# Altium schematic sheet SolderIronOut.SchDoc
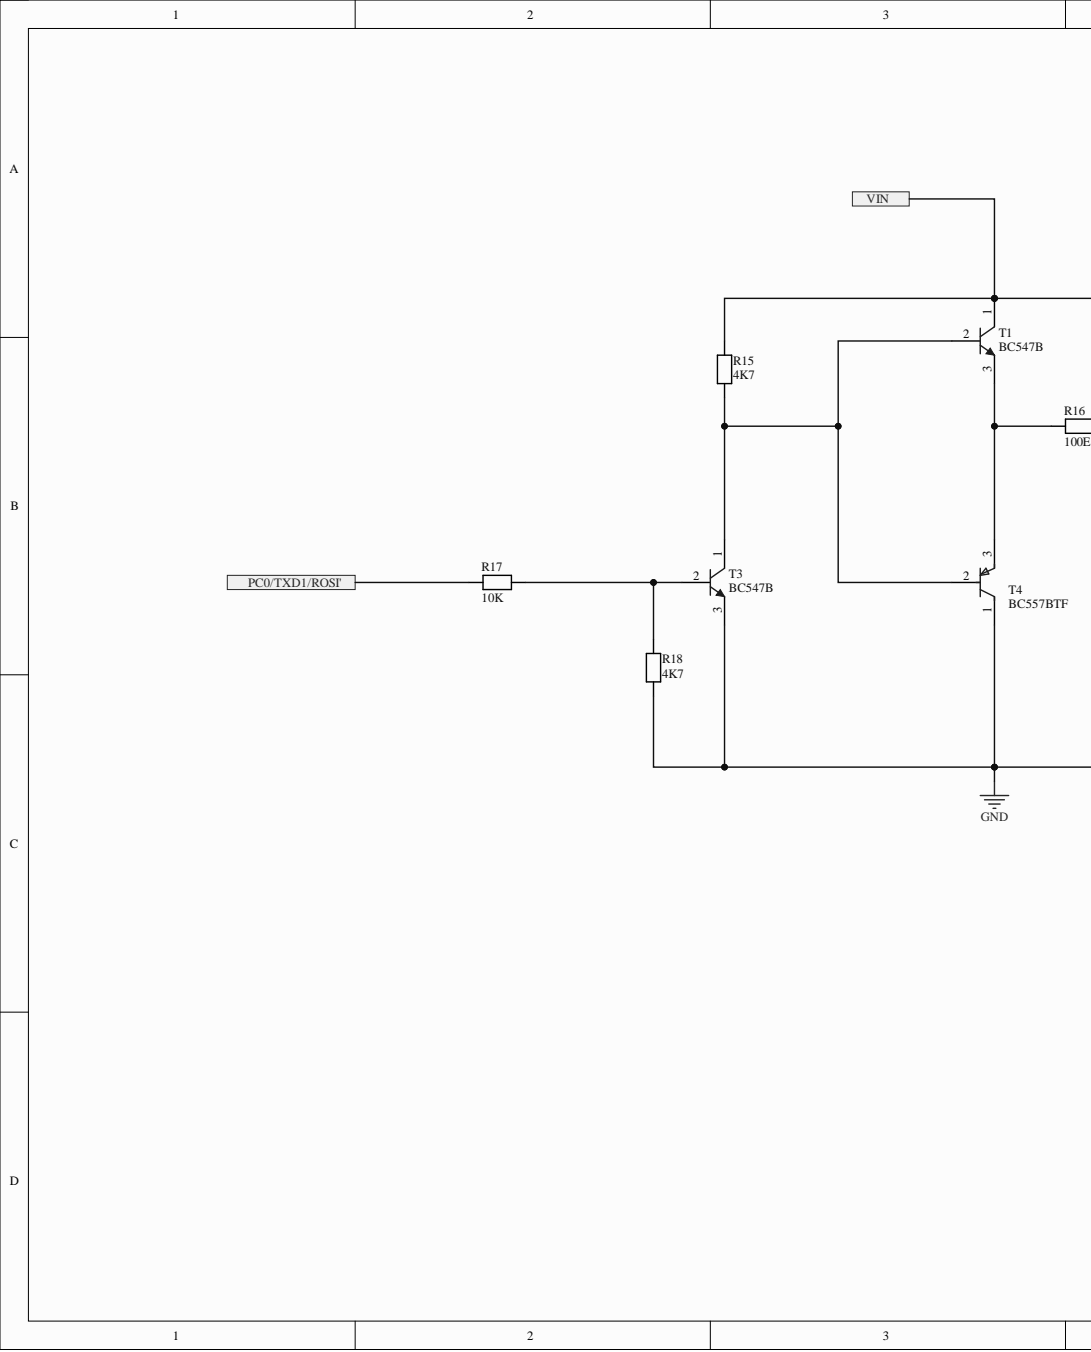
[diagram p0: SolderIronOut.SchDoc, left]
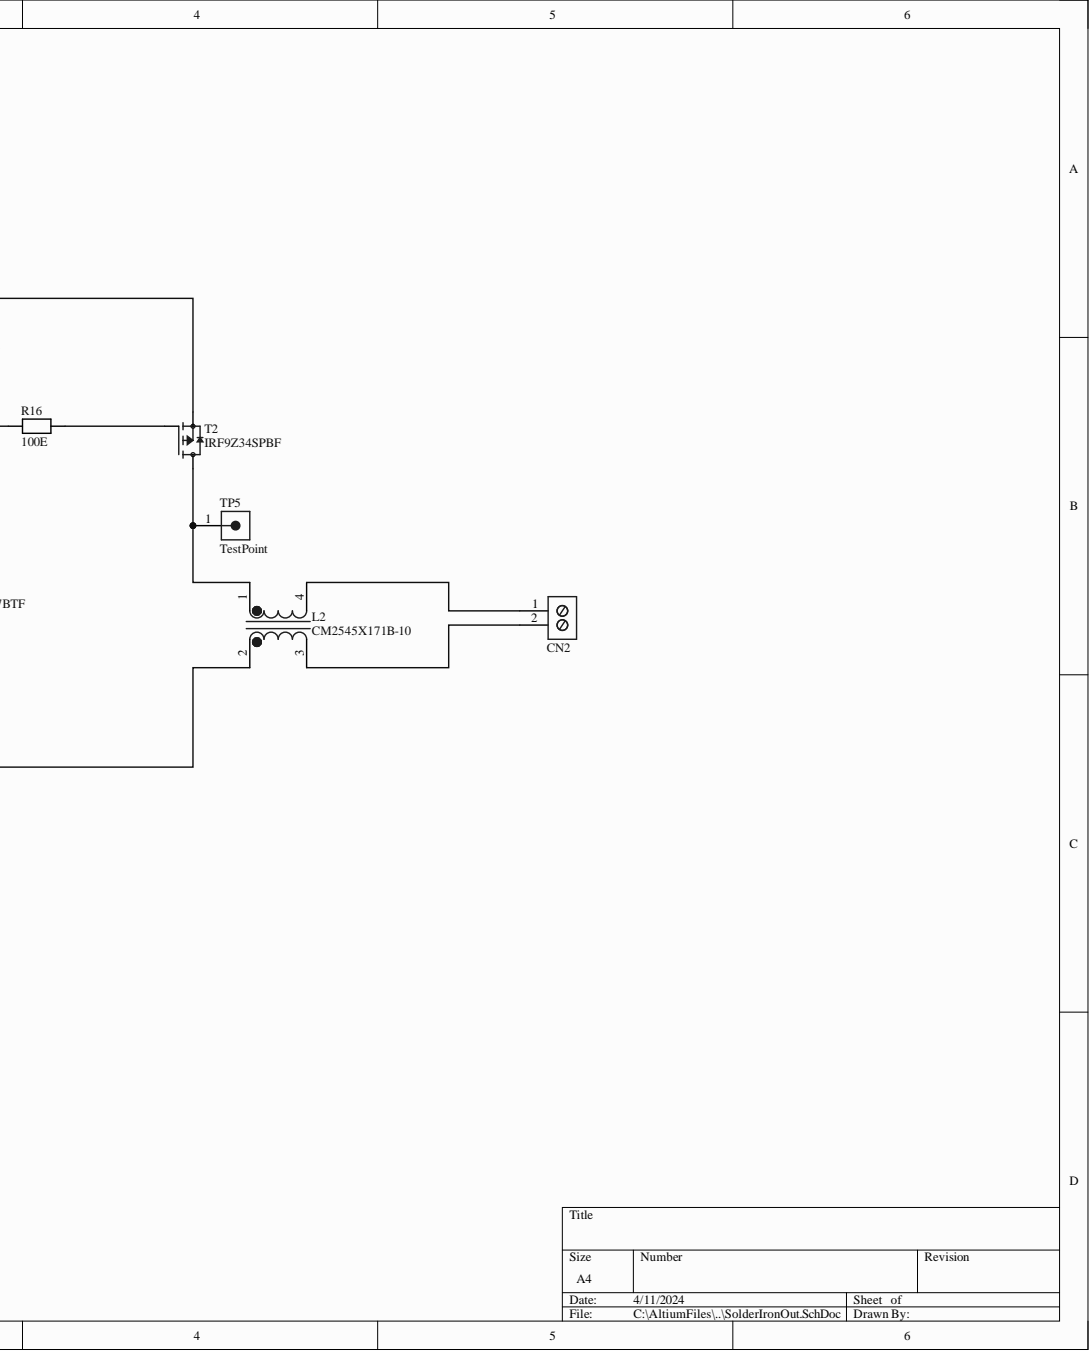
[diagram p1: SolderIronOut.SchDoc, right]

## Parts
CN2  Printkroonsteen 2 POL  [Printkroonsteen 2pin]  (value not drawn)
L2  CM2545X171B-10  [XFMR_CM2545X171B-10]
R15  4K7  [RESC2013X50X30LL20T20]
R16  100E  [RESC2013X50X30LL20T20]
R17  10K  [RESC2013X50X30LL20T20]
R18  4K7  [RESC2013X50X30LL20T20]
T1  BC547B  [ONSC-TO-92-3-29-11]
T2  IRF9Z34SPBF  [TO254P1521X483-4N]
T3  BC547B  [ONSC-TO-92-3-29-11]
T4  BC557BTF  [ONSC-TO-92-3-29-11]
TP5  TestPoint  [61300111121]

## Ports
PC0/TXD1/ROSI', VIN

## Nets
GND: L2.2 R18.1 T3.3 T4.1
PC0/TXD1/ROSI': R17.2 ; port PC0/TXD1/ROSI'
VIN: R15.2 T1.1 T2.3 ; port VIN
NetCN2_1: CN2.1 L2.4
NetCN2_2: CN2.2 L2.3
NetL2_1: L2.1 T2.4 TP5.1
NetR15_1: R15.1 T1.2 T3.1 T4.2
NetR16_1: R16.1 T2.1
NetR16_2: R16.2 T1.3 T4.3
NetR17_1: R17.1 R18.2 T3.2

## Library data of the parts
CN2 = printkroonsteen 1 X 2: Printkroonsteen 2 PO L1716020000; Manufacturer_Name: Weidmuller; Component Kind: Standard; Datasheet Link: https://catalog.weidmueller.com/catalog/Start.do?ObjectID=1716020000&_ga=2.21797499.1716783809.1613378202-511725545.1613378202; Library Name: SolderStation.SchLib; Manufacturer_Part_Number: 1716020000; Mouser Part Number: 470-1716020000; Mouser Price/Stock: https://www.mouser.co.uk/ProductDetail/Weidmuller/1716020000?qs=WJDKaGZ8K%252B7GSEFQgvMjVA%3D%3D
L2 = CM2545X171B-10: 2 Line Common Mode Choke Through Hole 170 Ohms @ 100 MHz 10A DCR 10mOhm; MF: Laird; Availability: In Stock; Check_prices: https://www.snapeda.com/parts/CM2545X171B-10/Laird/view-part/?ref=eda; Component Kind: Standard; Library Name: SolderStation.SchLib; MP: CM2545X171B-10; Package: Vertical-4 Laird; Price: None; Purchase-URL: https://pricing.snapeda.com/search/part/CM2545X171B-10/?ref=eda; SnapEDA_Link: https://www.snapeda.com/parts/CM2545X171B-10/Laird/view-part/?ref=snap
R15-R18 = resistor 0805: Manufacturer: Vishay Dale; Part Number: CRCW08050000Z0EA; Component Kind: Standard; Library Name: SolderStation.SchLib
T1 T3 = Transistor NPN BC547B THT: Amplifier Transistor, NPN Silicon, 3-Pin TO-92, Bulk Box CMP-1048-01467-1; Manufacturer: On Semiconductor; fT Min (MHz): 150; IC Continuous (A): 0.1; Component Kind: Standard; ComponentLink1Description: Manufacturer URL; ComponentLink1URL: http://www.onsemi.com/; ComponentLink2Description: Datasheet; ComponentLink2URL: http://www.onsemi.com/pub_link/Collateral/BC546-D.PDF; ComponentLink3Description: Package Specification; ComponentLink3URL: http://www.onsemi.cn/pub_link/Collateral/2N6426-D.PDF; DatasheetVersion: Rev. 7; Library Name: SolderStation.SchLib; Mounting Technology: Through Hole; PackageDescription: 3-Pin Transistor Outline, Body 4.825 x 3.685 mm, Pitch 1.27 mm; PackageReference: TO-92-3-29-11_AM_SL; PackageVersion: Rev. AM; Packing: Bulk Box; PartNumber: BC547B; Polarity: NPN; RoHS: FALSE
T2 = IRF9Z34SPBF: P-Channel 60V 18A _Tc_ 3.7W _Ta_, 88W _Tc_ Surface Mount D2PAK; MF: Vishay; Availability: Unavailable; Component Kind: Standard; Library Name: SolderStation.SchLib; MP: IRF9Z34SPBF; Package: D2PAK Vishay; Price: None; SnapEDA_Link: https://www.snapeda.com/parts/IRF9Z34SPBF/Vishay%20Siliconix/view-part/390212/?ref=snap
T4 = Transistor PNP BC557 THT: BC557BTF Small Signal Bipolar Transistor, 0.1A I(C), 45V V(BR)CEO, 1-Element, PNP, Silicon, TO-92 CMP-00278-84408945-1; Manufacturer Lifecycle Status: ACTIVE; Collector Base Voltage (VCBO): -50V; Collector Emitter Saturation Voltage: -250mV; Current Rating: -100mA; Element Configuration: Single; Emitter Base Voltage (VEBO): -5V; Frequency: 150MHz; hFE Min: 110; Max Collector Current: 100mA; Max Frequency: 10MHz; Max Power Dissipation: 500mW; Number of Elements: 1; Voltage Rating (DC): -45V; Case/Package: TO-92; Collector Emitter Breakdown Voltage: 45V; Collector Emitter Voltage (VCEO): 45V; Component Kind: Standard; Contact Plating: Tin; Gain Bandwidth Product: 150MHz; Height: 5.33mm; Lead Free: Lead Free; Length: 5.2mm; Library Name: SolderStation.SchLib; Lifecycle Status: Production; Max Breakdown Voltage: 45V; Max Operating Temperature: 150°C; Min Operating Temperature: -65°C; Mount: Through Hole; NexarPartId: 84408945; Number of Pins: 3; Polarity: PNP; Power Dissipation: 500mW; REACH SVHC: No SVHC; RoHS: Compliant; Schedule B: 8541210080, 8541210080|8541210080|8541210080|8541210080|8541210080; Transition Frequency: 150MHz; Weight: 240mg; Width: 4.19mm
TP5 = TestPoint: NexarPartId: 32855518; Connector Type: Male Pin; Contact Resistance: 20mΩ; Current Rating: 3A; Gender: Male; Number of Contacts: 1; Operating Supply Voltage: 250V; Color: Black; Component Kind: Standard; Contact Material: Copper; Contact Mating Length: 5.9944mm; Contact Plating: Gold; Lead Pitch: 2.54mm; Library Name: SolderStation.SchLib; Mount: Through Hole; Number of Pins: 1; Number of Positions: 1; Number of Rows: 1; Orientation: Vertical; Packaging: Bulk; REACH SVHC: Unknown; RoHS: Compliant; Termination: Solder
